# Altium schematic sheet Display.SchDoc
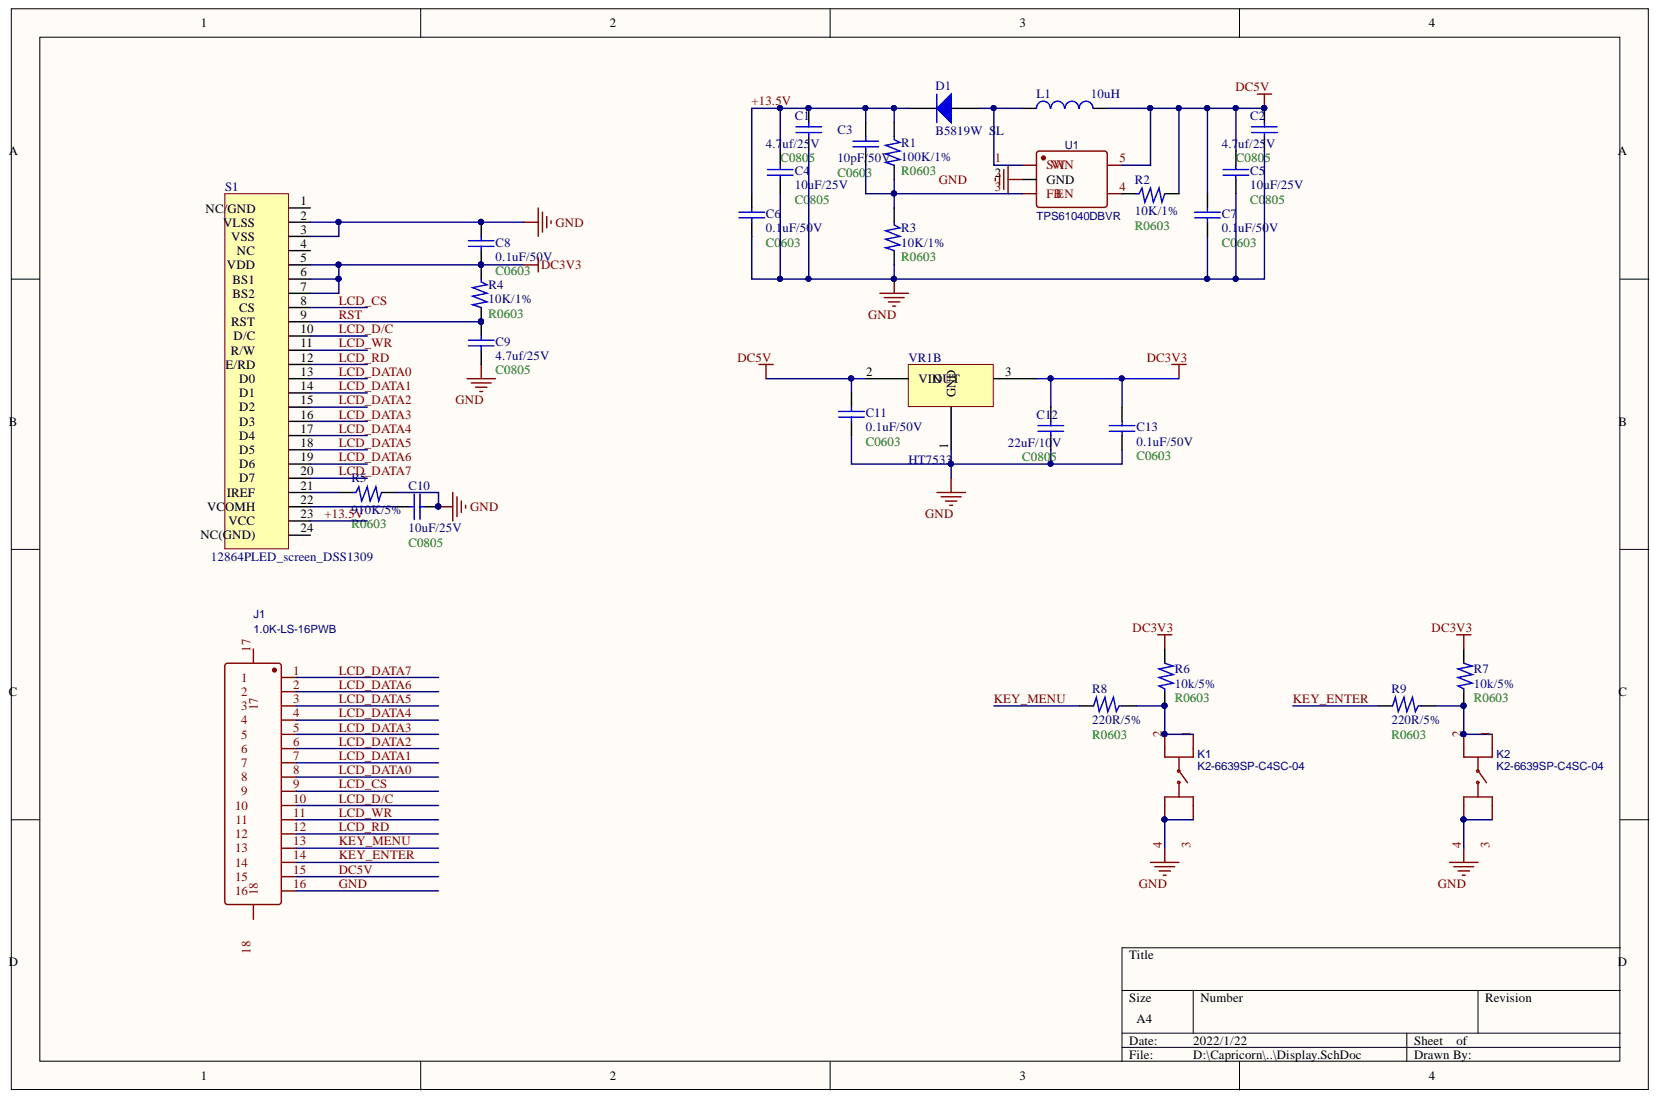
[diagram p0: Display.SchDoc]

## Parts
C1  4.7uf/25V  [C0805]
C2  4.7uf/25V  [C0805]
C3  10pF/50V  [C0603]
C4  10uF/25V  [C0805]
C5  10uF/25V  [C0805]
C6  0.1uF/50V  [C0603]
C7  0.1uF/50V  [C0603]
C8  0.1uF/50V  [C0603]
C9  4.7uf/25V  [C0805]
C10  10uF/25V  [C0805]
C11  0.1uF/50V  [C0603]
C12  22uF/10V  [C0805]
C13  0.1uF/50V  [C0603]
D1  B5819W  SL  [SOD-123]
J1  1.0K-LS-16PWB  [FPC-SMD_KH-CL0.5-H2.0-16PS]
K1  K2-6639SP-C4SC-04  [SW-TH_4P-L6.0-W6.0-P4.50-LS6.5]
K2  K2-6639SP-C4SC-04  [SW-TH_4P-L6.0-W6.0-P4.50-LS6.5]
L1  10uH  [L-SPD]
R1  100K/1%  [R0603]
R2  10K/1%  [R0603]
R3  10K/1%  [R0603]
R4  10K/1%  [R0603]
R5  910K/5%  [R0603]
R6  10k/5%  [R0603]
R7  10k/5%  [R0603]
R8  220R/5%  [R0603]
R9  220R/5%  [R0603]
S1  12864PLED_screen_DSS1309  [FPC-SMD_KH-FG0.5-H2.0-24PIN]
U1  TPS61040DBVR  [SOT-23-5]
VR1  HT7533  [SOT-89(SOT-89-3)]  (part B)

## Nets
DC3V3: C8.1 C12.2 C13.2 R4.2 R6.1 R7.1 S1.5 S1.6 S1.7 VR1.3
+13.5V: C1.2 C3.2 C4.1 C6.2 D1.2 R1.2 S1.23
DC5V: C2.2 C5.1 C7.2 C11.2 J1.15 L1.1 R2.1 U1.5 VR1.2(VIN)
GND: C1.1 C2.1 C4.2 C5.2 C6.1 C7.1 C8.2 C9.1 C10.1 C11.1 C12.1 C13.1 J1.16 K1.3 K1.4 K2.3 K2.4 R3.1 R5.1 S1.2 S1.3 U1.2(GND) VR1.1(GND)
KEY_ENTER: J1.14 R9.1
KEY_MENU: J1.13 R8.1
LCD_CS: J1.9 S1.8
LCD_D/C: J1.10 S1.10
LCD_DATA0: J1.8 S1.13
LCD_DATA1: J1.7 S1.14
LCD_DATA2: J1.6 S1.15
LCD_DATA3: J1.5 S1.16
LCD_DATA4: J1.4 S1.17
LCD_DATA5: J1.3(3_17) S1.18
LCD_DATA6: J1.2 S1.19
LCD_DATA7: J1.1 S1.20
LCD_RD: J1.12 S1.12
LCD_WR: J1.11 S1.11
RST: C9.2 R4.1 S1.9
NetC3_1: C3.1 R1.1 R3.2 U1.3(FB)
NetC10_2: C10.2 S1.22
NetD1_1: D1.1 L1.2 U1.1(SW)
NetK1_1: K1.1 K1.2 R6.2 R8.2
NetK2_1: K2.1 K2.2 R7.2 R9.2
NetR2_2: R2.2 U1.4
NetR5_2: R5.2 S1.21

Not connected: J1 17 18; S1 1 4 24

## Library data of the parts
C1-C13 = CAP: Capacitor; Component Kind: Standard
D1 = SOD-123 B5819W  SL: 肖特基二极管; Component Kind: Standard; ComponentLink1Description: 供应商链接; ComponentLink1URL: http://www.szlcsc.com/product/details_9093.html; Library Name: Miscellaneous Devices LC.IntLib; Package: SOD-123; Supplier: LC; SuppliersPartNumber: C8598
J1 = 1.0K-LS-16PWB: BOM_Manufacturer: HDGC(华德共创); Contributor: LCSC; BOM_Manufacturer Part: 1.0K-LS-16PWB; BOM_Supplier: LCSC; BOM_Supplier Part: C2915465; Component Kind: Standard; spicePre: F; spiceSymbolName: 1.0K-LS-16PWB
K1 K2 = K2-6639SP-C4SC-04: BOM_Manufacturer: HRO; BOM_Assembly Type: smtWeld; BOM_Paste Type: expand; BOM_Manufacturer Part: K2-6639SP-C4SC-04; BOM_Supplier: LCSC; BOM_Supplier Part: C83206; Component Kind: Standard; spicePre: S; spiceSymbolName: K2-6639SP-C4SC-04
L1 = L: Component Kind: Standard
R1-R9 = 电阻: Component Kind: Standard
U1 = TPS61040DBVR: BOM_Manufacturer: TI; SMT Type: Basic; BOM_LCSC Assembly: Yes; BOM_Manufacturer Part: TPS61040DBVR; BOM_Supplier: LCSC; BOM_Supplier Part: C7722; Component Kind: Standard; image: //image.lceda.cn/szlcsc/C7722.jpg; spicePre: U; spiceSymbolName: TPS61040DBVR
S1 = 12864PLED_screen_DSS1309: Component Kind: Standard; Library Name: MySCHlib.SchLib
VR1 = HT7533: Component Kind: Standard; Library Name: Schlib1.SchLib
